# Altium schematic sheet AT2560circuit.SchDoc
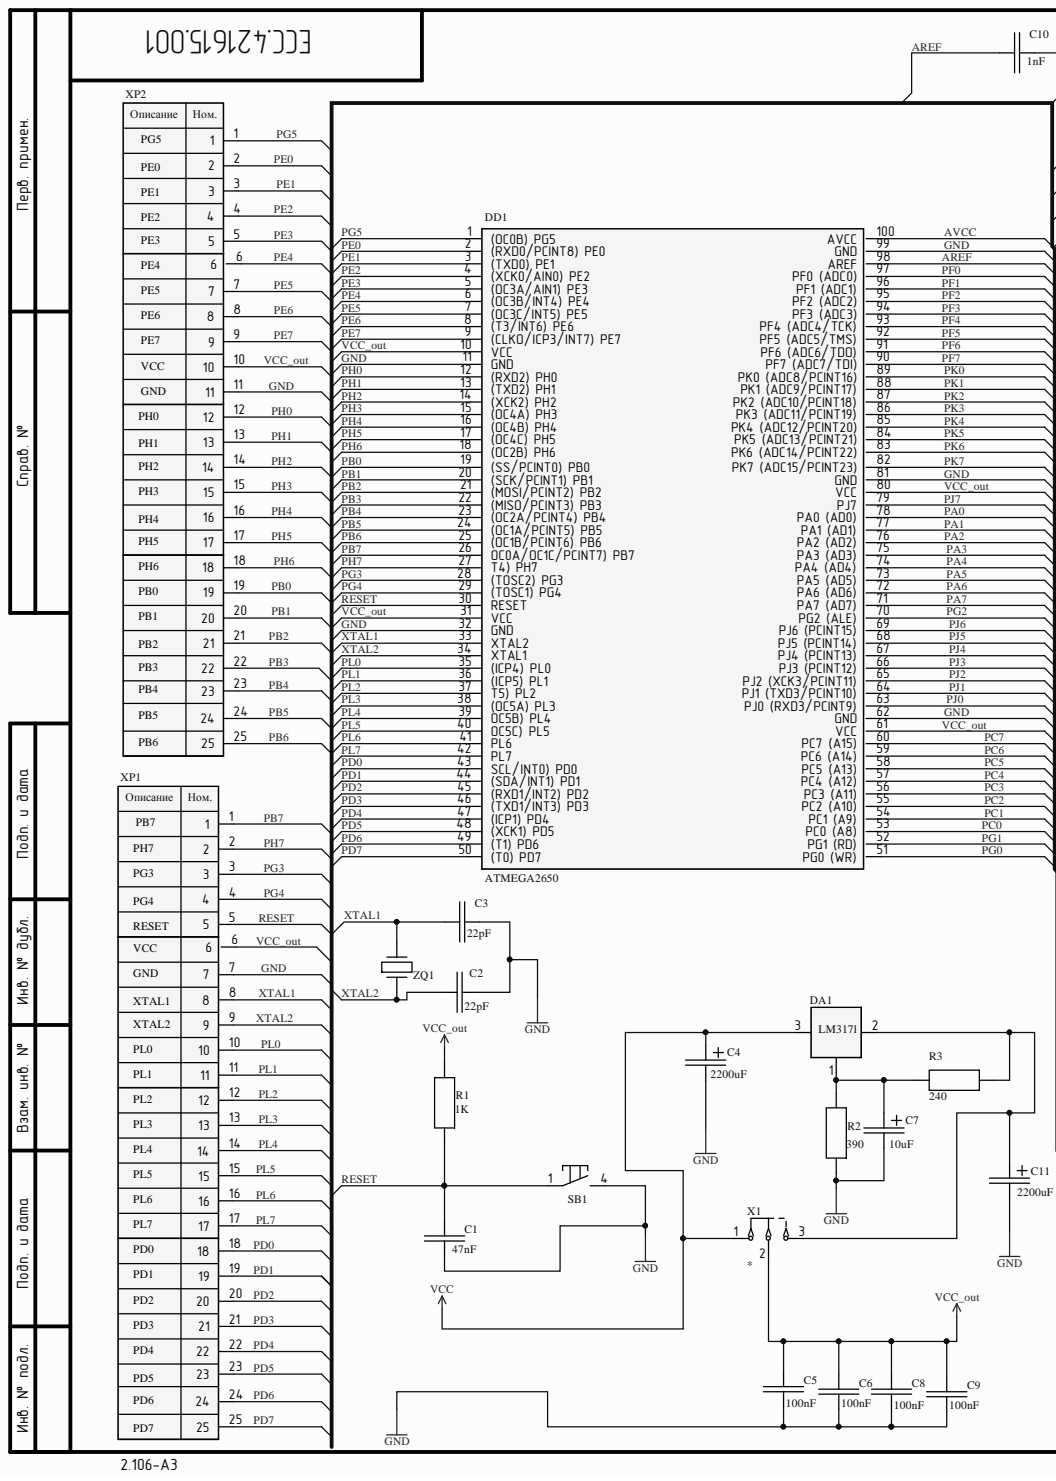
[diagram p0: AT2560circuit.SchDoc, left]
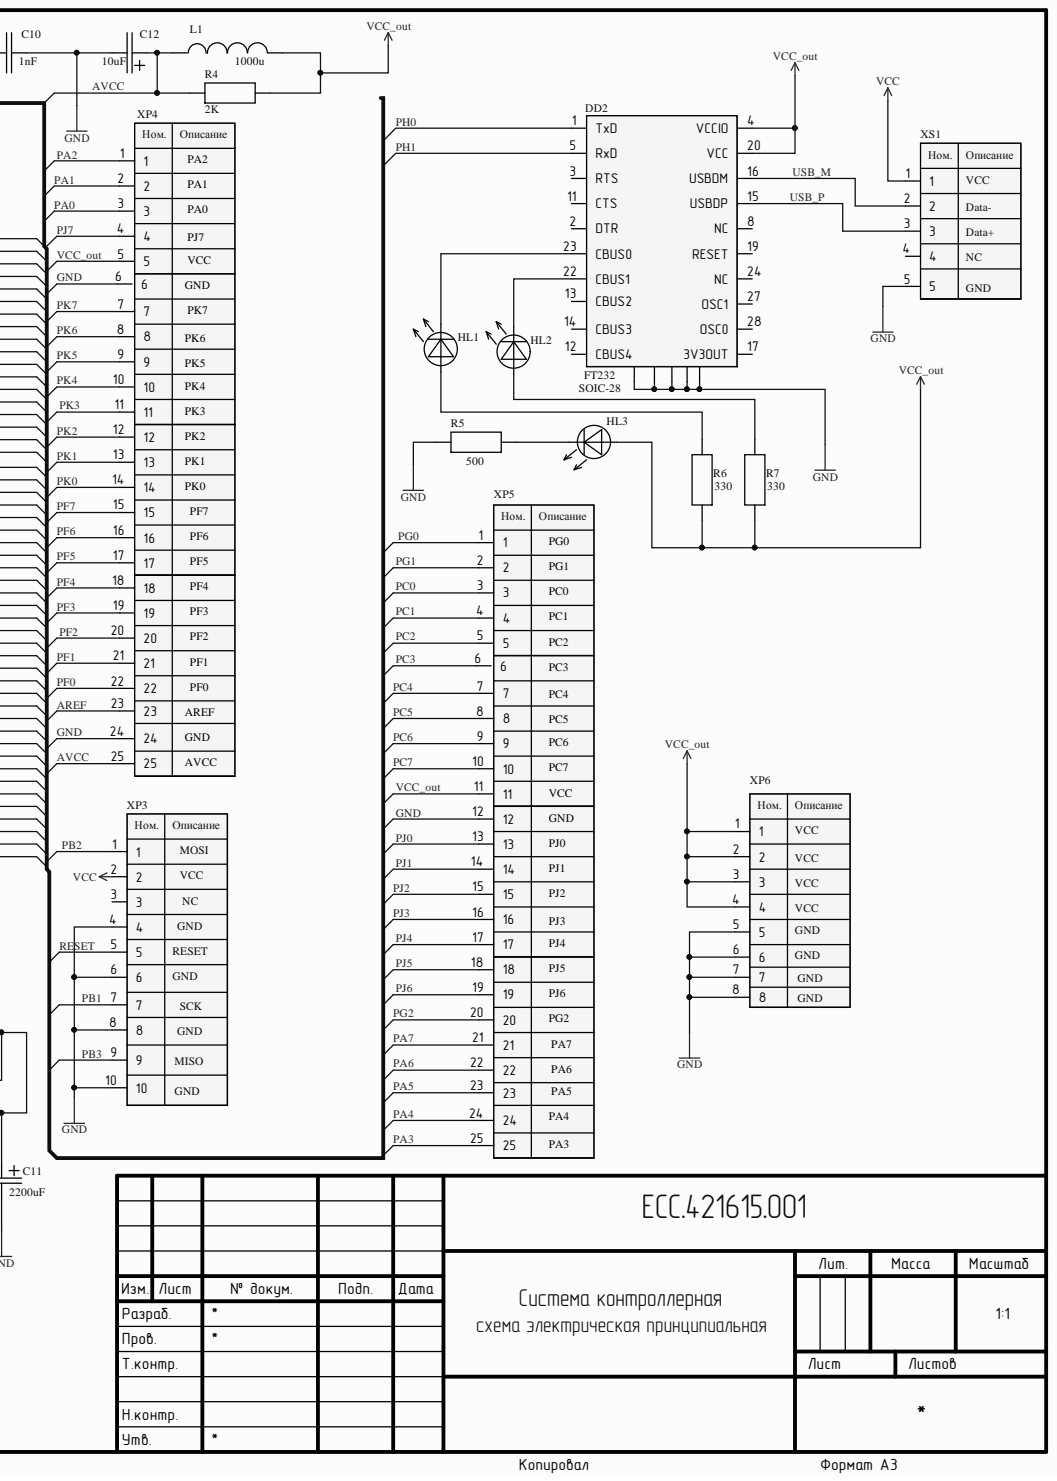
[diagram p1: AT2560circuit.SchDoc, right]

## Parts
C1  Номинал: 47nF  [CAPC2012L]  (hidden comment: Capasitor)
C2  Номинал: 22pF  [CAPC2012L]  (hidden comment: Capasitor)
C3  Номинал: 22pF  [CAPC2012L]  (hidden comment: Capasitor)
C4  Номинал: 2200uF  [K50-Elect]  (hidden comment: Electrolit_capasitor)
C5  Номинал: 100nF  [CAPC2012L]  (hidden comment: Capasitor)
C6  Номинал: 100nF  [CAPC2012L]  (hidden comment: Capasitor)
C7  Номинал: 10uF  [K50-Elect]  (hidden comment: Electrolit_capasitor)
C8  Номинал: 100nF  [CAPC2012L]  (hidden comment: Capasitor)
C9  Номинал: 100nF  [CAPC2012L]  (hidden comment: Capasitor)
C10  Номинал: 1nF  [CAPC2012L]  (hidden comment: Capasitor)
C11  Номинал: 2200uF  [K50-Elect]  (hidden comment: Electrolit_capasitor)
C12  Номинал: 10uF  [K50-Elect]  (hidden comment: Electrolit_capasitor)
DA1  LM317l  [TO-263-3]
DD1  Марка: ATMEGA2650  [TSQFP50P1600X1600X120-100N]  (hidden comment: ATMega2560)
DD2  FT232  Корпус: SOIC-28  [SSOP-28]
HL1  LED  [LED]  (value not drawn)
HL2  LED  [LED]  (value not drawn)
HL3  LED  [LED]  (value not drawn)
L1  Номинал: 1000u  [Drossel]  (hidden comment: Inductor)
R1  Номинал: 1K  [RESC2012L]  (hidden comment: Resistor)
R2  Resistor  [RESC2012L]  (value not drawn)
R3  Resistor  [RESC2012L]  (value not drawn)
R4  Номинал: 2K  [RESC2012L]  (hidden comment: Resistor)
R5  Resistor  [RESC2012L]  (value not drawn)
R6  Resistor  [RESC2012L]  (value not drawn)
R7  Resistor  [RESC2012L]  (value not drawn)
SB1  button  [KLS2]  (value not drawn)
X1  jumper  [PLSx3_XP]  (value not drawn)
XP1  Connector_plsx25  [PLSx25_XP]  (value not drawn)
XP2  Connector_plsx25  [PLSx25_XP]  (value not drawn)
XP3  pls-6  [PLS6-2]  (value not drawn)
XP4  Connector_plsx25  [PLSx25_XP]  (value not drawn)
XP5  Connector_plsx25  [PLSx25_XP]  (value not drawn)
XP6  Power_Connector  [PLS-8-2]  (value not drawn)
XS1  USB_mini_B  [KLS1-229-5FE]  (value not drawn)
ZQ1  Rezanator  [STS]  (value not drawn)

## Nets
VCC: C4.1 DA1.3 X1.1 XP3.2 XS1.1
AREF: C10.1 DD1.98(AREF) XP4.23
AVCC: C12.1 DD1.100(AVCC) L1.1 R4.1 XP4.25
GND: C1.2 C2.1 C3.1 C4.2 C5.2 C6.2 C7.2 C8.2 C9.2 C10.2 C11.2 C12.2 DD1.11(GND) DD1.32(GND) DD1.62(GND) DD1.81(GND) DD1.99(GND) DD2.7 DD2.18 DD2.21 DD2.25 DD2.26 R2.1 R5.1 SB1.4 XP1.7 XP2.11 XP3.4 XP3.6 XP3.8 XP3.10 XP4.6 XP4.24 XP5.12 XP6.5 XP6.6 XP6.7 XP6.8 XS1.5
PA0: DD1.78((AD0)) XP4.3
PA1: DD1.77((AD1)) XP4.2
PA2: DD1.76((AD2)) XP4.1
PA3: DD1.75((AD3)) XP5.25
PA4: DD1.74((AD4)) XP5.24
PA5: DD1.73((AD5)) XP5.23
PA6: DD1.72((AD6)) XP5.22
PA7: DD1.71((AD7)) XP5.21
PB0: DD1.19((SS/PCINT0)) XP2.19
PB1: DD1.20((SCK/PCINT1)) XP2.20 XP3.7
PB2: DD1.21((MOSI/PCINT2)) XP2.21 XP3.1
PB3: DD1.22((MISO/PCINT3)) XP2.22 XP3.9
PB4: DD1.23((OC2A/PCINT4)) XP2.23
PB5: DD1.24((OC1A/PCINT5)) XP2.24
PB6: DD1.25((OC1B/PCINT6)) XP2.25
PB7: DD1.26(OC0A/OC1C/PCINT7)) XP1.1
PC0: DD1.53((A8)) XP5.3
PC1: DD1.54((A9)) XP5.4
PC2: DD1.55((A10)) XP5.5
PC3: DD1.56((A11)) XP5.6
PC4: DD1.57((A12)) XP5.7
PC5: DD1.58((A13)) XP5.8
PC6: DD1.59((A14)) XP5.9
PC7: DD1.60((A15)) XP5.10
PD0: DD1.43(SCL/INT0)) XP1.18
PD1: DD1.44((SDA/INT1)) XP1.19
PD2: DD1.45((RXD1/INT2)) XP1.20
PD3: DD1.46((TXD1/INT3)) XP1.21
PD4: DD1.47((ICP1)) XP1.22
PD5: DD1.48((XCK1)) XP1.23
PD6: DD1.49((T1)) XP1.24
PD7: DD1.50((T0)) XP1.25
PE0: DD1.2((RXD0/PCINT8)) XP2.2
PE1: DD1.3((TXD0)) XP2.3
PE2: DD1.4((XCK0/AIN0)) XP2.4
PE3: DD1.5((OC3A/AIN1)) XP2.5
PE4: DD1.6((OC3B/INT4)) XP2.6
PE5: DD1.7((OC3C/INT5)) XP2.7
PE6: DD1.8((T3/INT6)) XP2.8
PE7: DD1.9((CLKO/ICP3/INT7)) XP2.9
PF0: DD1.97((ADC0)) XP4.22
PF1: DD1.96((ADC1)) XP4.21
PF2: DD1.95((ADC2)) XP4.20
PF3: DD1.94((ADC3)) XP4.19
PF4: DD1.93((ADC4/TCK)) XP4.18
PF5: DD1.92((ADC5/TMS)) XP4.17
PF6: DD1.91((ADC6/TDO)) XP4.16
PF7: DD1.90((ADC7/TDI)) XP4.15
PG0: DD1.51((WR)) XP5.1
PG1: DD1.52((RD)) XP5.2
PG2: DD1.70((ALE)) XP5.20
PG3: DD1.28((TOSC2)) XP1.3
PG4: DD1.29((TOSC1)) XP1.4
PG5: DD1.1((OC0B)) XP2.1
PH0: DD1.12((RXD2)) DD2.1(TxD) XP2.12
PH1: DD1.13((TXD2)) DD2.5(RxD) XP2.13
PH2: DD1.14((XCK2)) XP2.14
PH3: DD1.15((OC4A)) XP2.15
PH4: DD1.16((OC4B)) XP2.16
PH5: DD1.17((OC4C)) XP2.17
PH6: DD1.18((OC2B)) XP2.18
PH7: DD1.27(T4)) XP1.2
PJ0: DD1.63((RXD3/PCINT9)) XP5.13
PJ1: DD1.64((TXD3/PCINT10)) XP5.14
PJ2: DD1.65((XCK3/PCINT11)) XP5.15
PJ3: DD1.66((PCINT12)) XP5.16
PJ4: DD1.67((PCINT13)) XP5.17
PJ5: DD1.68((PCINT14)) XP5.18
PJ6: DD1.69((PCINT15)) XP5.19
PJ7: DD1.79(PJ7) XP4.4
PK0: DD1.89((ADC8/PCINT16)) XP4.14
PK1: DD1.88((ADC9/PCINT17)) XP4.13
PK2: DD1.87((ADC10/PCINT18)) XP4.12
PK3: DD1.86((ADC11/PCINT19)) XP4.11
PK4: DD1.85((ADC12/PCINT20)) XP4.10
PK5: DD1.84((ADC13/PCINT21)) XP4.9
PK6: DD1.83((ADC14/PCINT22)) XP4.8
PK7: DD1.82((ADC15/PCINT23)) XP4.7
PL0: DD1.35((ICP4)) XP1.10
PL1: DD1.36((ICP5)) XP1.11
PL2: DD1.37(T5)) XP1.12
PL3: DD1.38((OC5A)) XP1.13
PL4: DD1.39(OC5B)) XP1.14
PL5: DD1.40(OC5C)) XP1.15
PL6: DD1.41(PL6) XP1.16
PL7: DD1.42(PL7) XP1.17
RESET: C1.1 DD1.30(RESET) R1.1 SB1.1 XP1.5 XP3.5
USB_M: DD2.16(USBDM) XS1.2
USB_P: DD2.15(USBDP) XS1.3
VCC_out: C5.1 C6.1 C8.1 C9.1 DD1.10(VCC) DD1.31(VCC) DD1.61(VCC) DD1.80(VCC) DD2.4(VCCIO) DD2.20(VCC) HL3.1 L1.2 R1.2 R4.2 R6.1 R7.1 X1.2 XP1.6 XP2.10 XP4.5 XP5.11 XP6.1 XP6.2 XP6.3 XP6.4
XTAL1: C3.2 DD1.33(XTAL2) XP1.8 ZQ1.2
XTAL2: C2.2 DD1.34(XTAL1) XP1.9 ZQ1.1
NetC7_1: C7.1 DA1.1 R2.2 R3.2
NetC11_1: C11.1 DA1.2 R3.1 X1.3
NetDD2_22: DD2.22(CBUS1) HL2.2
NetDD2_23: DD2.23(CBUS0) HL1.2
NetHL1_1: HL1.1 R6.2
NetHL2_1: HL2.1 R7.2
NetHL3_2: HL3.2 R5.2
N00004:

Not connected: DD2 2(DTR) 3(RTS) 8(NC) 11(CTS) 12(CBUS4) 13(CBUS2) 14(CBUS3) 17(3V3OUT) 19(RESET) 24(NC) 27(OSC1) 28(OSC0); XP3 3; XS1 4

## Library data of the parts
DA1 = LM317l: Корпус: TO-263; Component Kind: Standard; Library Name: SchlibUGO.SchLib
DD1 = ATMega2560: Корпус: TQFP100; Component Kind: Standard; Library Name: SchlibUGO.SchLib
DD2 = FT232: Производитель: Texas Instruments; Component Kind: Standard; марка: FT232; Library Name: SchlibUGO.SchLib
R2 = Resistor: Номинал: 390; Component Kind: Standard; Library Name: SchlibUGO.SchLib
R3 = Resistor: Номинал: 240; Component Kind: Standard; Library Name: SchlibUGO.SchLib
R5 = Resistor: Номинал: 500; Component Kind: Standard; Library Name: SchlibUGO.SchLib
R6 R7 = Resistor: Номинал: 330; Component Kind: Standard; Library Name: SchlibUGO.SchLib
C1-C3 C5 C6 C8-C10 = Capasitor: Component Kind: Standard; Library Name: SchlibUGO.SchLib
C4 C7 C11 C12 = Electrolit_capasitor: Component Kind: Standard; Library Name: SchlibUGO.SchLib
HL1-HL3 = LED: Component Kind: Standard; Library Name: SchlibUGO.SchLib
L1 = Inductor: Component Kind: Standard; Library Name: SchlibUGO.SchLib
R1 R4 = Resistor: Component Kind: Standard; Library Name: SchlibUGO.SchLib
SB1 = button: Component Kind: Standard; Library Name: SchlibUGO.SchLib
X1 = jumper: Component Kind: Standard; Library Name: SchlibUGO.SchLib
XP1 XP2 XP4 XP5 = Connector_plsx25: Component Kind: Standard; Library Name: SchlibUGO.SchLib
XP3 = pls-6: Component Kind: Standard; Library Name: SchlibUGO.SchLib
XP6 = Power_Connector: Component Kind: Standard; Library Name: SchlibUGO.SchLib
XS1 = USB_mini_B: Component Kind: Standard; Library Name: SchlibUGO.SchLib
ZQ1 = Rezanator: Component Kind: Standard; Library Name: SchlibUGO.SchLib
